# Altium schematic sheet dsPIC.SchDoc
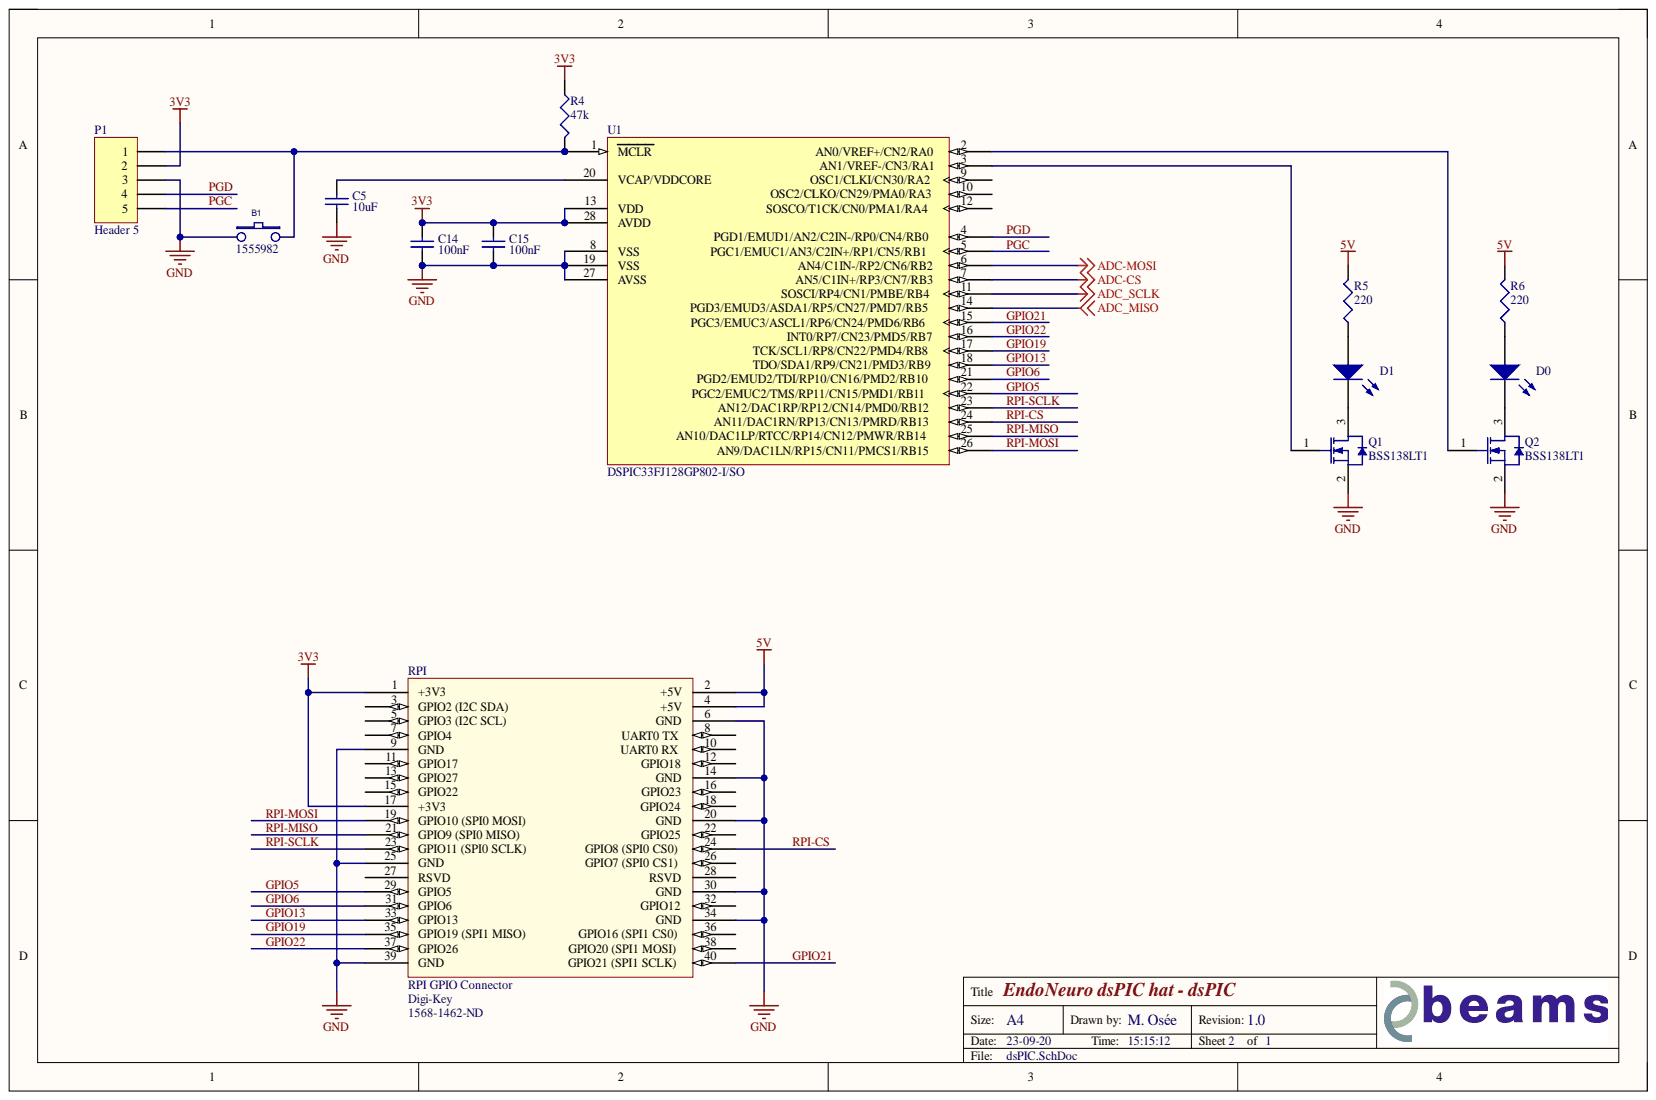
[diagram p0: dsPIC.SchDoc]

## Parts
B1  Supplier Part Number 1: 1555982  [FSM4JH]  (hidden comment: FSM4JH)
C5  value 10uF  [C0805]  (hidden comment: Cap Semi)
C14  value 100nF  [C0805]  (hidden comment: Cap Semi)
C15  value 100nF  [C0805]  (hidden comment: Cap Semi)
D0  LED3  [3.5X2.8X1.9]  (value not drawn)
D1  LED3  [3.5X2.8X1.9]  (value not drawn)
P1  Header 5  [HDR1X5]
Q1  BSS138LT1  [ONSC-SOT-23-3-318-08_V]
Q2  BSS138LT1  [ONSC-SOT-23-3-318-08_V]
R4  value 47k  [6-0805_M]  (hidden comment: Res3)
R5  value 220  [J1-0603]  (hidden comment: Res3)
R6  value 220  [J1-0603]  (hidden comment: Res3)
RPI  RPI GPIO Connector  Accessory Type: Connector  Supplier 1: Digi-Key  [rpi]
U1  DSPIC33FJ128GP802-I/SO  [SOIC-SO28_N]

## Nets
3V3: C14.2 C15.2 P1.2 R4.1 RPI.1(+3V3) RPI.17(+3V3) U1.13(VDD) U1.28(AVDD)
5V: R5.2 R6.2 RPI.2(+5V) RPI.4(+5V)
ADC-CS: U1.7(AN5/C1IN+/RP3/CN7/RB3)
ADC-MOSI: U1.6(AN4/C1IN-/RP2/CN6/RB2)
ADC_MISO: U1.14(PGD3/EMUD3/ASDA1/RP5/CN27/PMD7/RB5)
ADC_SCLK: U1.11(SOSCI/RP4/CN1/PMBE/RB4)
GND: B1.1 C5.1 C14.1 C15.1 P1.3 Q1.2 Q2.2 RPI.6(GND) RPI.9(GND) RPI.14(GND) RPI.20(GND) RPI.25(GND) RPI.30(GND) RPI.34(GND) RPI.39(GND) U1.8(VSS) U1.19(VSS) U1.27(AVSS)
GPIO5: RPI.29(GPIO5) U1.22(PGC2/EMUC2/TMS/RP11/CN15/PMD1/RB11)
GPIO6: RPI.31(GPIO6) U1.21(PGD2/EMUD2/TDI/RP10/CN16/PMD2/RB10)
GPIO13: RPI.33(GPIO13) U1.18(TDO/SDA1/RP9/CN21/PMD3/RB9)
GPIO19: RPI.35(GPIO19_(SPI1_MISO)) U1.17(TCK/SCL1/RP8/CN22/PMD4/RB8)
GPIO21: RPI.40(GPIO21_(SPI1_SCLK)) U1.15(PGC3/EMUC3/ASCL1/RP6/CN24/PMD6/RB6)
GPIO22: RPI.37(GPIO26) U1.16(INT0/RP7/CN23/PMD5/RB7)
PGC: P1.5 U1.5(PGC1/EMUC1/AN3/C2IN+/RP1/CN5/RB1)
PGD: P1.4 U1.4(PGD1/EMUD1/AN2/C2IN-/RP0/CN4/RB0)
RPI-CS: RPI.24(GPIO8_(SPI0_CS0)) U1.24(AN11/DAC1RN/RP13/CN13/PMRD/RB13)
RPI-MISO: RPI.21(GPIO9_(SPI0_MISO)) U1.25(AN10/DAC1LP/RTCC/RP14/CN12/PMWR/RB14)
RPI-MOSI: RPI.19(GPIO10_(SPI0_MOSI)) U1.26(AN9/DAC1LN/RP15/CN11/PMCS1/RB15)
RPI-SCLK: RPI.23(GPIO11_(SPI0_SCLK)) U1.23(AN12/DAC1RP/RP12/CN14/PMD0/RB12)
NetB1_2: B1.2 P1.1 R4.2 U1.1(MCLR)
NetC5_2: C5.2 U1.20(VCAP/VDDCORE)
NetD0_1: D0.1 R6.1
NetD0_2: D0.2 Q2.3
NetD1_1: D1.1 R5.1
NetD1_2: D1.2 Q1.3
NetQ1_1: Q1.1 U1.3(AN1/VREF-/CN3/RA1)
NetQ2_1: Q2.1 U1.2(AN0/VREF+/CN2/RA0)

Not connected: RPI 3(GPIO2_(I2C_SDA)) 5(GPIO3_(I2C_SCL)) 7(GPIO4) 8(UART0_TX) 10(UART0_RX) 11(GPIO17) 12(GPIO18) 13(GPIO27) 15(GPIO22) 16(GPIO23) 18(GPIO24) 22(GPIO25) 26(GPIO7_(SPI0_CS1)) 27(RSVD) 28(RSVD) 32(GPIO12) 36(GPIO16_(SPI1_CS0)) 38(GPIO20_(SPI1_MOSI)); U1 9(OSC1/CLKI/CN30/RA2) 10(OSC2/CLKO/CN29/PMA0/RA3) 12(SOSCO/T1CK/CN0/PMA1/RA4)

## Library data of the parts
B1 = FSM4JH: Push Button Switch; Component Kind: Standard; Supplier 1: Farnell; Library Name: discrets.IntLib
C5 C14 C15 = Cap Semi: Capacitor (Semiconductor SIM Model); Component Kind: Standard; LatestRevisionDate: 29-May-2009; LatestRevisionNote: IPC-7351 Footprint Added.; Library Name: Miscellaneous Devices.IntLib; PackageDescription: Chip Capacitor; PackageReference: C1206; Published: 8-Jun-2000; Simulation: CAP; Publisher: Altium Limited
D0 D1 = LED3: Typical BLUE SiC LED; Component Kind: Standard; DatasheetVersion: 16-Apr-2002; LatestRevisionDate: 17-Dec-2003; LatestRevisionNote: PCB Footprint replaced.; Library Name: Miscellaneous Devices.IntLib; PackageDescription: SM LED; 2 C-Bend Leads; Body 3.5 x 2.8 x 1.9 mm, inc leads (LxWxH typ); PackageReference: 3.5X2.8X1.9; PackageVersion: 16-Apr-2002; Published: 8-Jun-2000; Simulation: LED3; Publisher: Altium Limited
P1 = Header 5: Header, 5-Pin; Component Kind: Standard; LatestRevisionDate: 17-Jul-2002; LatestRevisionNote: Re-released for DXP Platform.; Library Name: Miscellaneous Connectors.IntLib; Publisher: Altium Limited
Q1 Q2 = BSS138LT1: Power MOSFET, 200 mA, 50 V, N-Channel, 3-Pin SOT-23, Tape and Reel; Manufacturer: On Semiconductor; Channel Polarity: N Channel; Ciss Typ (pF): 40; Configuration: Single; Coss Typ (pF): 12; Crss Typ (pF): 3.5; ID Max (A): 0.2; PD Max (W): 0.225; V(BR)DSS Min (V): 50; VGS Max (V): 20; VGS(th) Max (V): 1.5; Component Kind: Standard; ComponentLink1Description: Manufacturer URL; ComponentLink1URL: http://www.onsemi.com/; ComponentLink2Description: Datasheet; ComponentLink2URL: http://www.onsemi.com/pub_link/Collateral/BSS138LT1-D.PDF; ComponentLink3Description: Package Specification; ComponentLink3URL: http://www.onsemi.cn/pub_link/Collateral/318-08.PDF; DatasheetVersion: Rev. 8; Library Name: ON Semi MOSFETs.IntLib; Mounting Technology: Surface Mount; Package Type: SOT-23-3; PackageDescription: 3-Pin Small Outline Transistor, Body 2.92 x 1.3 mm, Pitch 0.95 mm; PackageReference: SOT-23-3-318-08; PackageVersion: Rev. AP, 11/2009; Packing: Tape and Reel; PartNumber: BSS138LT1; Supplier 1: Digi-Key; Supplier Part Number 1: BSS138LT1OSCT-ND
R4-R6 = Res3: Resistor; Component Kind: Standard; LatestRevisionDate: 29-May-2009; LatestRevisionNote: IPC-7351 Footprint Added.; Library Name: Miscellaneous Devices.IntLib; PackageDescription: Chip Resistor; PackageReference: J1-0603; Published: 8-Jun-2000; Simulation: RESISTOR; Publisher: Altium Limited
RPI = rpi connector: GPIO Header for Raspberry Pi A+/B+/Pi 2/Pi 3 - 2x20; Manufacturer: SparkFun Electronics; Manufacturer Part Number: PRT-14017; Nils Minor: author; nilsminor@web.de: mail; Category: Development Boards, Kits, Programmers; Component Kind: Standard; ComponentLink1Description: https://cdn.sparkfun.com/datasheets/Dev/RaspberryPi/12313.pdf; ComponentLink1URL: https://cdn.sparkfun.com/datasheets/Dev/RaspberryPi/12313.pdf; For Use With/Related Products: Raspberry Pi; Library Name: rpi.IntLib; Part Status: Active; Pricing 1: 1=1.84 (EUR); RoHS: RoHS Compliant; Stock 1: 150; Supplier Part Number 1: 1568-1462-ND
U1 = DSPIC33FJ128GP802-I/SO: High-Performance 16-bit Digital Signal Controller, 128 KB Flash, 16 KB RAM, 28-Pin SOIC, Industrial Temperature; Manufacturer: Microchip; Code_JEDEC: MS-013; Component Kind: Standard; ComponentLink1Description: Manufacturer URL; ComponentLink1URL: http://www.microchip.com/; ComponentLink2Description: Datasheet; ComponentLink2URL: http://ww1.microchip.com/downloads/en/DeviceDoc/70292D.pdf; ComponentLink3Description: Package Specification; ComponentLink3URL: http://www.microchip.com/stellent/groups/techpub_sg/documents/packagingspec/en012702.pdf; DatasheetVersion: revD, Nov-2009; Library Name: Microchip dsPIC33F.IntLib; Mounting Technology: Surface Mount; PackageDescription: 28-Lead Plastic Small Outline (SO) - Wide 7.50mm Body [SOIC]; PackageReference: SOIC-SO28; PackageVersion: revBB, Aug-2009; PartNumber: DSPIC33FJ128GP802-I/SO; Supplier 1: Digi-Key; Supplier 2: Farnell; Supplier 3: Newark; Supplier 4: Mouser; Supplier Part Number 1: DSPIC33FJ128GP802-I/SO-ND; Supplier Part Number 2: 1576799; Supplier Part Number 3: 39M8118; Supplier Part Number 4: 579-DSPIC128GP802ISO
